# Altium schematic sheet I2C.SchDoc
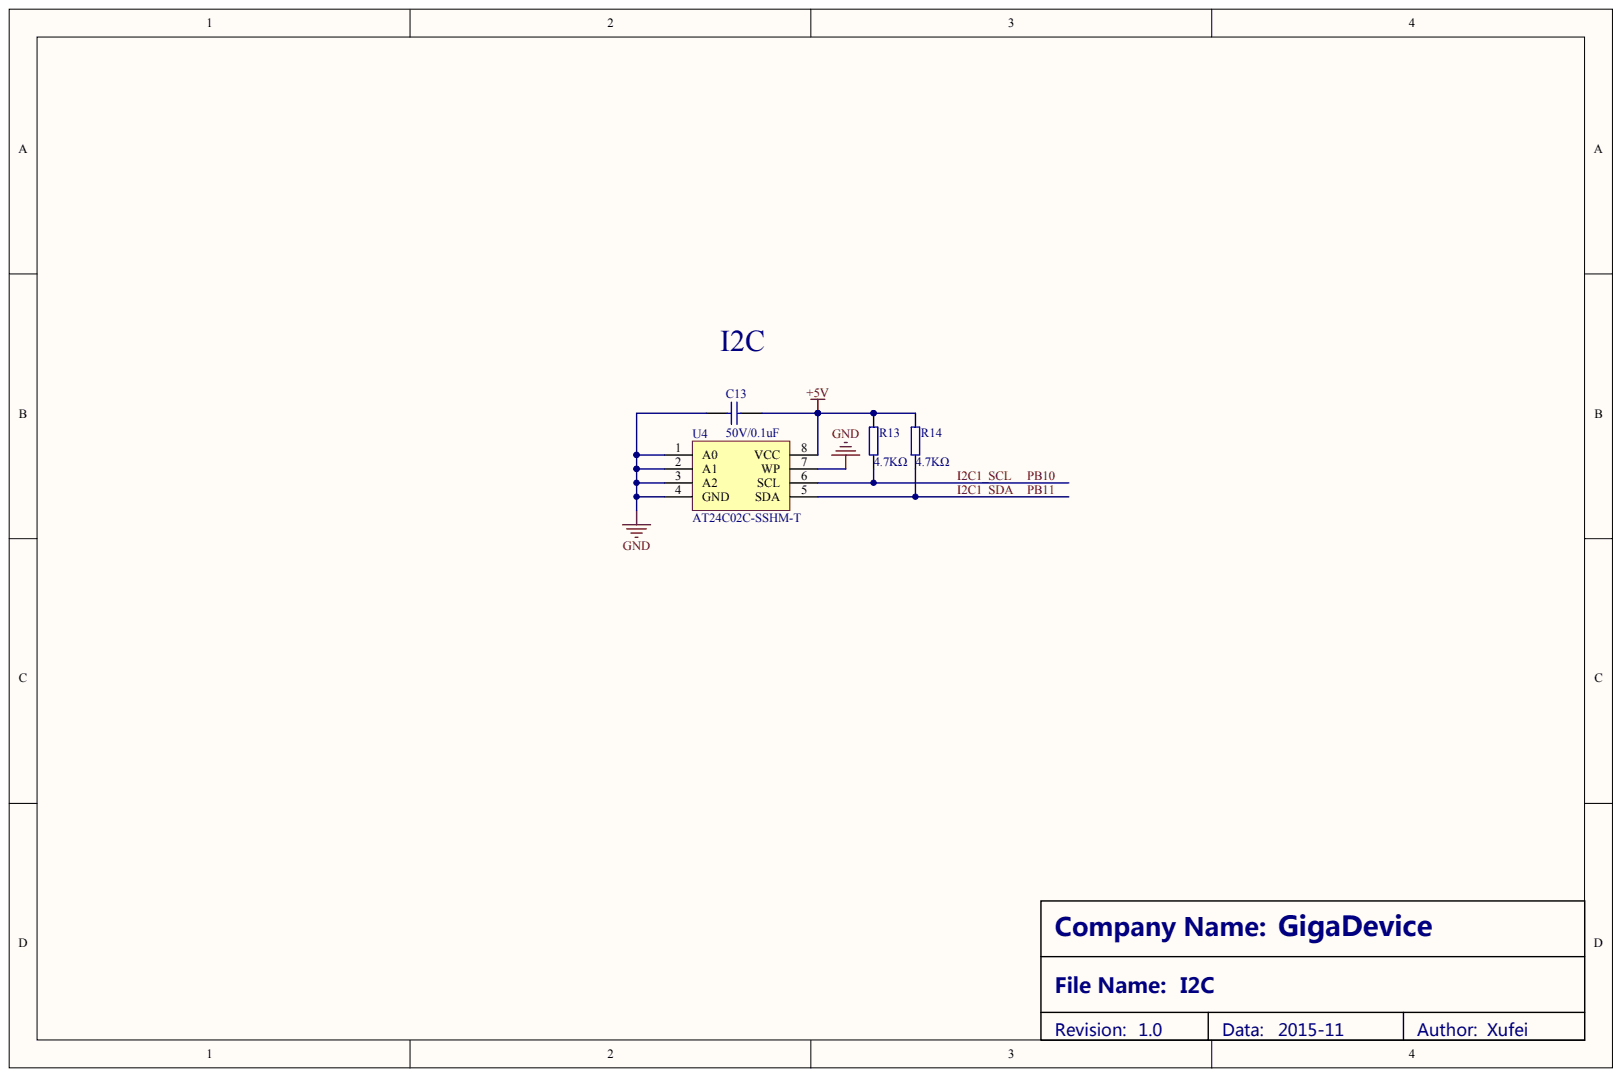
[diagram p0: I2C.SchDoc]

## Parts
C13  50V/0.1uF
R13  4.7KΩ
R14  4.7KΩ
U4  AT24C02C-SSHM-T

## Nets
+5V: C13.2 R13.2 R14.2 U4.8(VCC)
GND: C13.1 U4.1(A0) U4.2(A1) U4.3(A2) U4.4(GND) U4.7(WP)
I2C1_SCL: R13.1 U4.6(SCL)
I2C1_SDA: R14.1 U4.5(SDA)
